# Altium schematic sheet Chipolino_revA.SchDoc
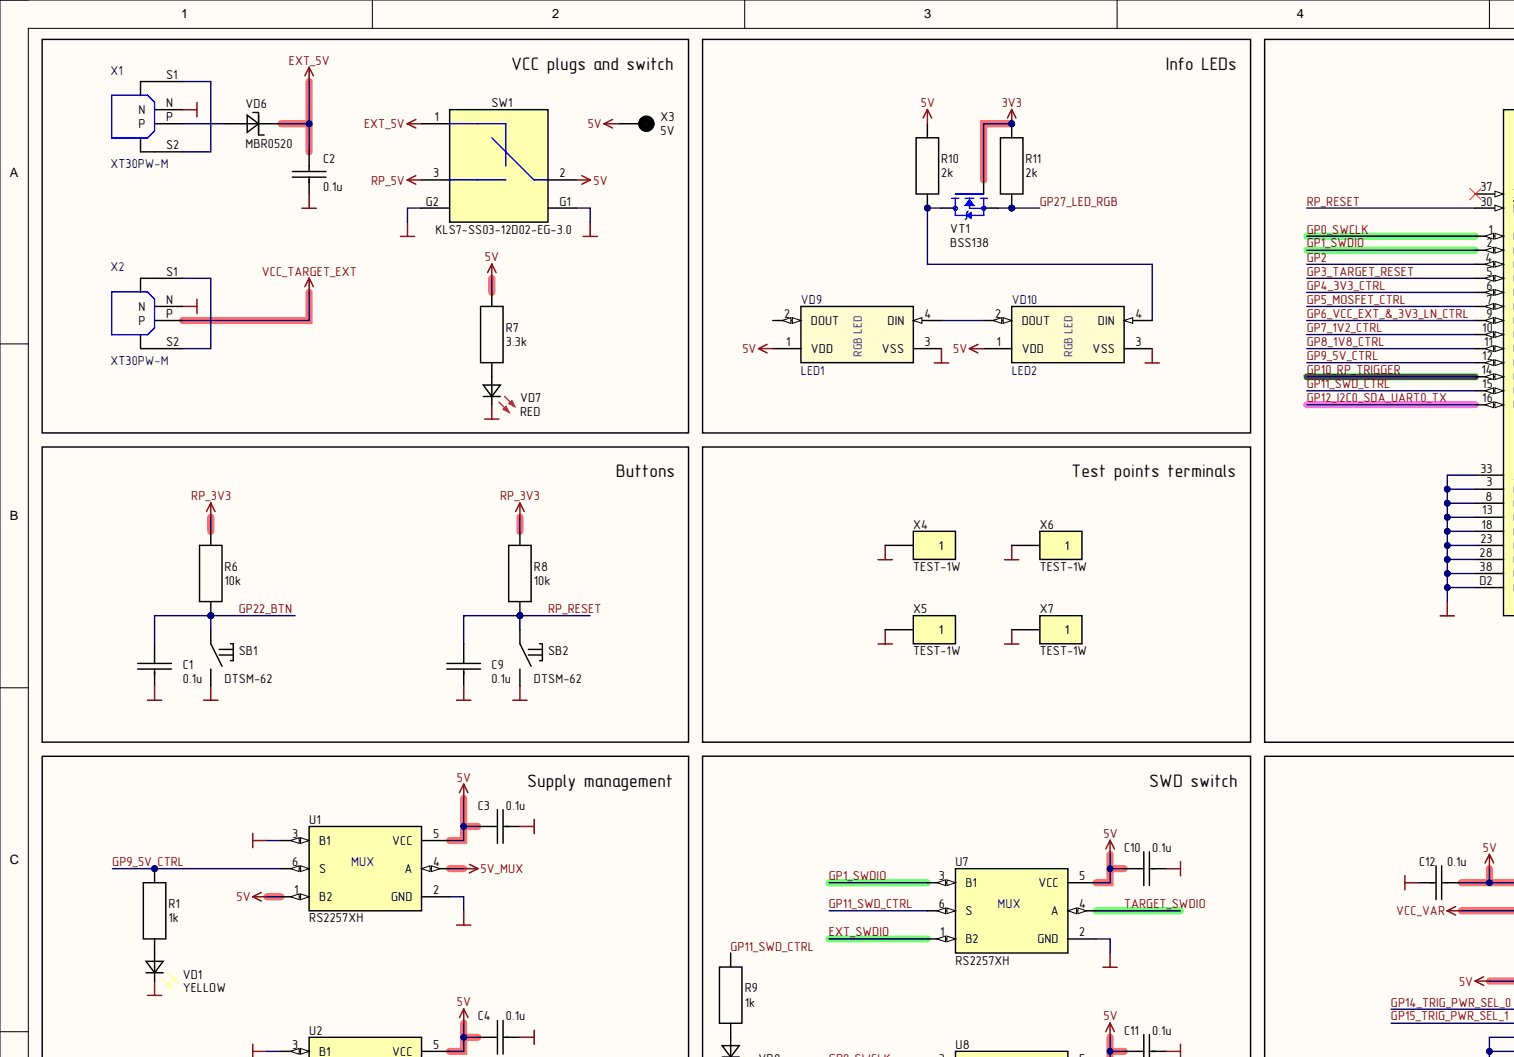
[diagram p0: Chipolino_revA.SchDoc, top left]
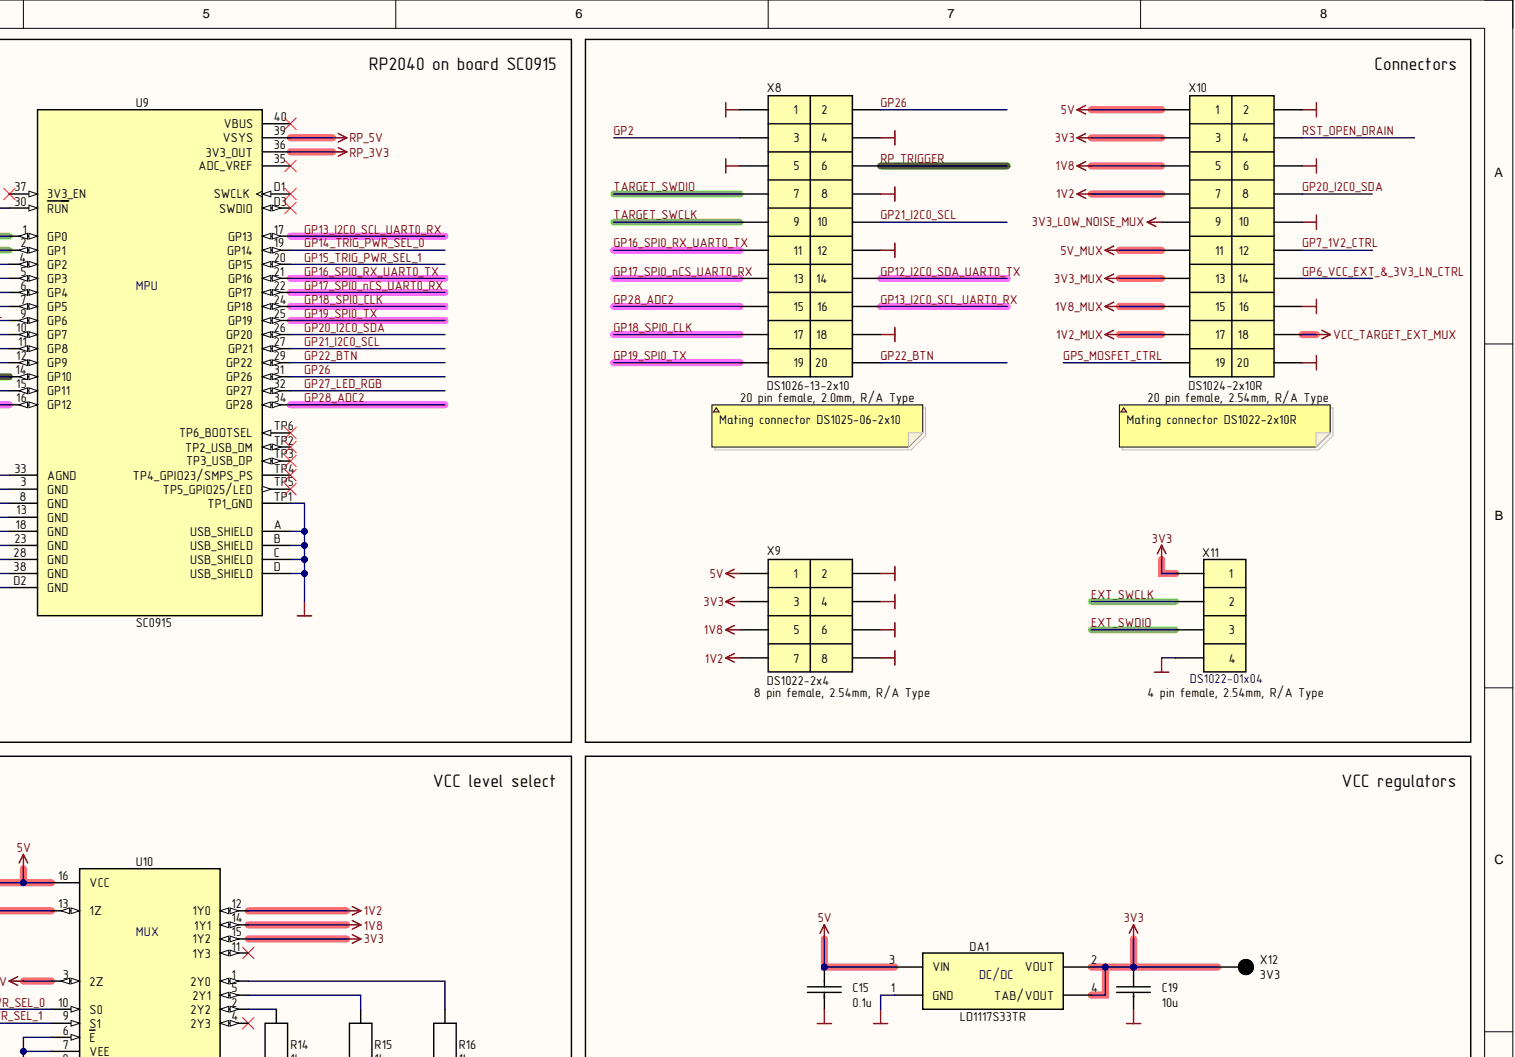
[diagram p1: Chipolino_revA.SchDoc, top right]
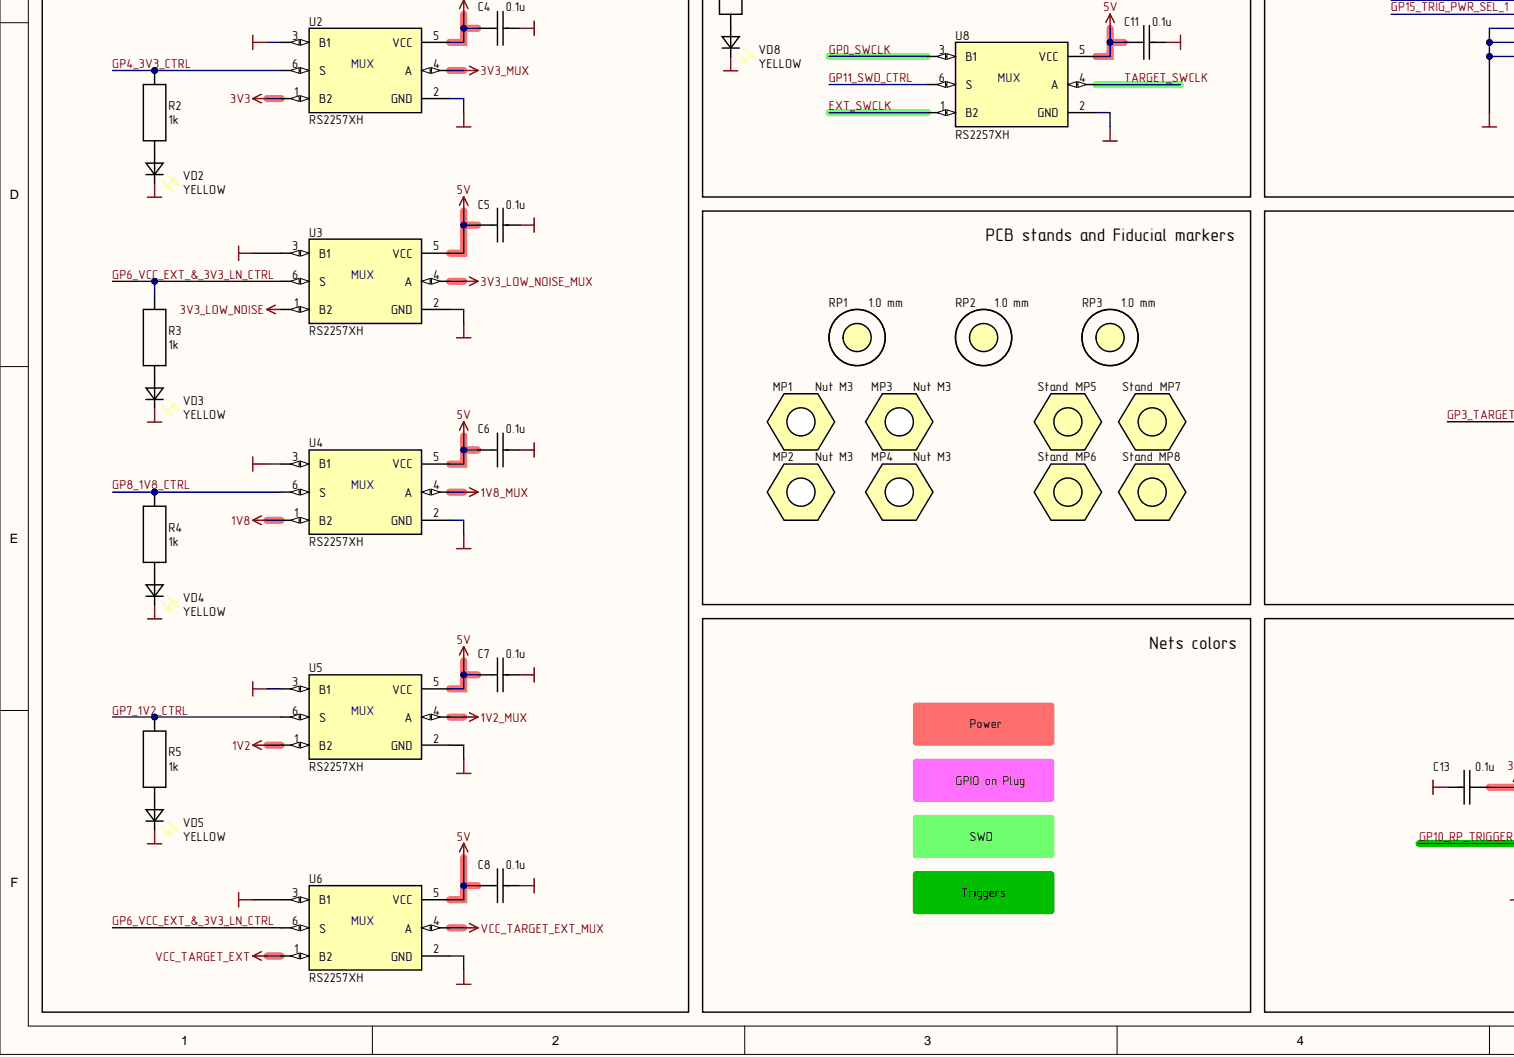
[diagram p2: Chipolino_revA.SchDoc, bottom left]
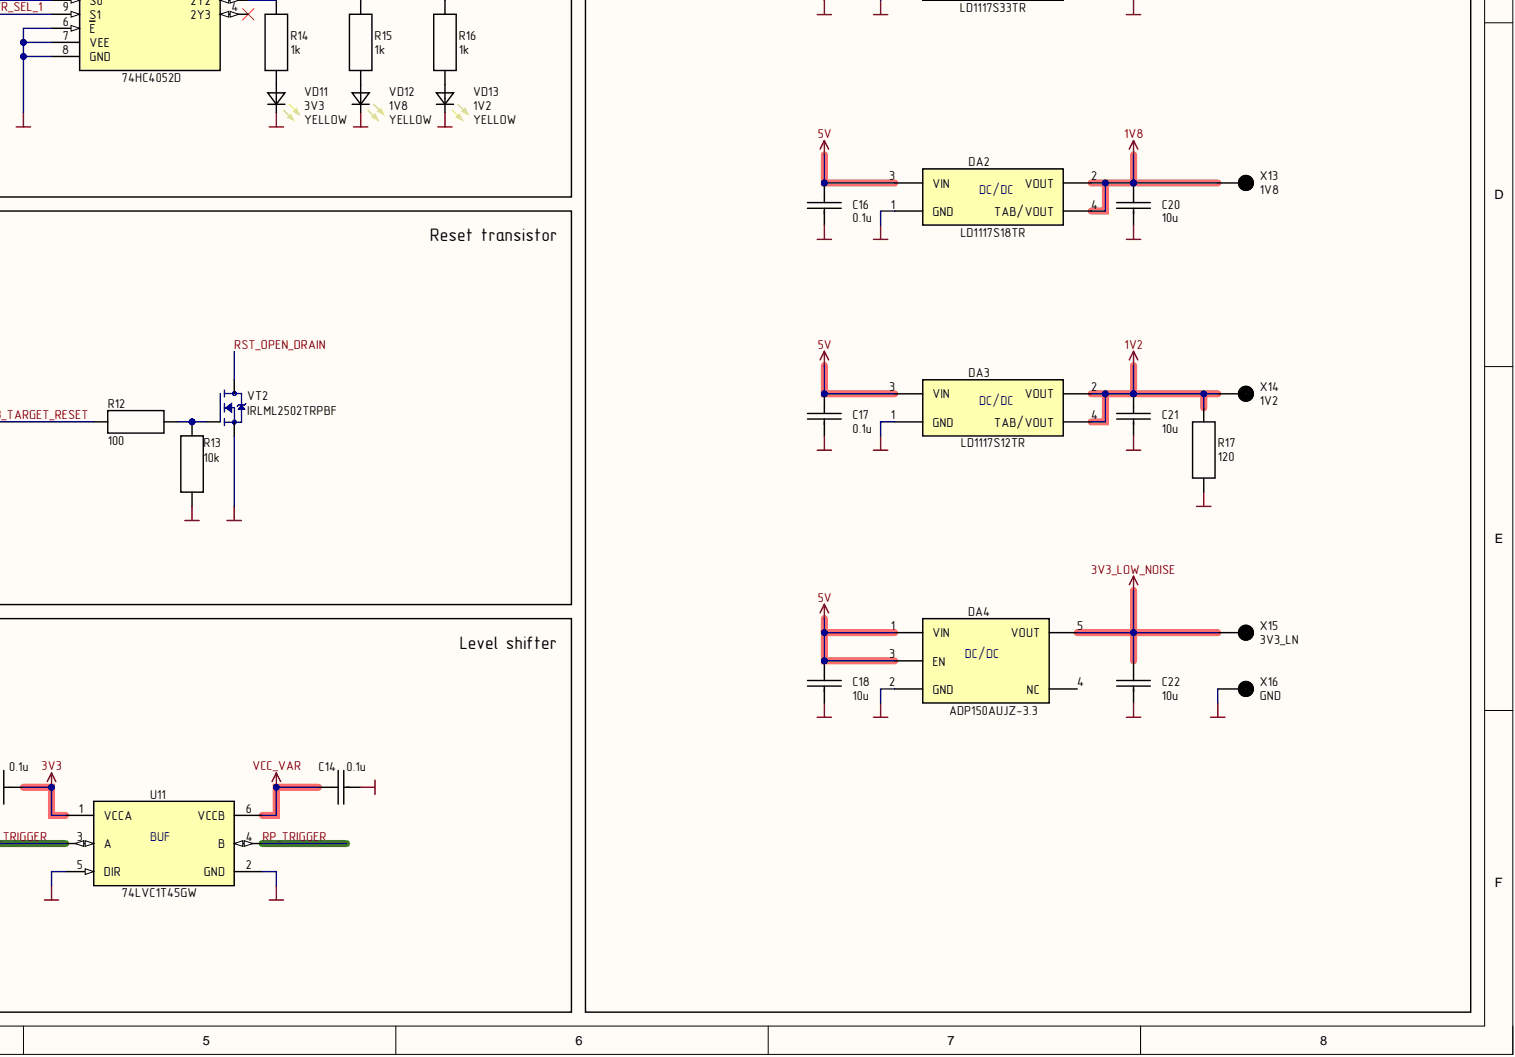
[diagram p3: Chipolino_revA.SchDoc, bottom right]

## Parts
C1  value 0.1u  [CH0603C]  (hidden comment: Ceramic capacitor, 0603, 0.1u, ±10%, 50V, X7R, -55...+125°C)
C2  value 0.1u  [CH0603C]  (hidden comment: Ceramic capacitor, 0603, 0.1u, ±10%, 50V, X7R, -55...+125°C)
C3  value 0.1u  [CH0603C]  (hidden comment: Ceramic capacitor, 0603, 0.1u, ±10%, 50V, X7R, -55...+125°C)
C4  value 0.1u  [CH0603C]  (hidden comment: Ceramic capacitor, 0603, 0.1u, ±10%, 50V, X7R, -55...+125°C)
C5  value 0.1u  [CH0603C]  (hidden comment: Ceramic capacitor, 0603, 0.1u, ±10%, 50V, X7R, -55...+125°C)
C6  value 0.1u  [CH0603C]  (hidden comment: Ceramic capacitor, 0603, 0.1u, ±10%, 50V, X7R, -55...+125°C)
C7  value 0.1u  [CH0603C]  (hidden comment: Ceramic capacitor, 0603, 0.1u, ±10%, 50V, X7R, -55...+125°C)
C8  value 0.1u  [CH0603C]  (hidden comment: Ceramic capacitor, 0603, 0.1u, ±10%, 50V, X7R, -55...+125°C)
C9  value 0.1u  [CH0603C]  (hidden comment: Ceramic capacitor, 0603, 0.1u, ±10%, 50V, X7R, -55...+125°C)
C10  value 0.1u  [CH0603C]  (hidden comment: Ceramic capacitor, 0603, 0.1u, ±10%, 50V, X7R, -55...+125°C)
C11  value 0.1u  [CH0603C]  (hidden comment: Ceramic capacitor, 0603, 0.1u, ±10%, 50V, X7R, -55...+125°C)
C12  value 0.1u  [CH0603C]  (hidden comment: Ceramic capacitor, 0603, 0.1u, ±10%, 50V, X7R, -55...+125°C)
C13  value 0.1u  [CH0603C]  (hidden comment: Ceramic capacitor, 0603, 0.1u, ±10%, 50V, X7R, -55...+125°C)
C14  value 0.1u  [CH0603C]  (hidden comment: Ceramic capacitor, 0603, 0.1u, ±10%, 50V, X7R, -55...+125°C)
C15  value 0.1u  [CH0603C]  (hidden comment: Ceramic capacitor, 0603, 0.1u, ±10%, 50V, X7R, -55...+125°C)
C16  value 0.1u  [CH0603C]  (hidden comment: Ceramic capacitor, 0603, 0.1u, ±10%, 50V, X7R, -55...+125°C)
C17  value 0.1u  [CH0603C]  (hidden comment: Ceramic capacitor, 0603, 0.1u, ±10%, 50V, X7R, -55...+125°C)
C18  value 10u  [CH0603C]  (hidden comment: Ceramic capacitor, 0603, 10u, ±10%, 16V, X7R, -55...+125°C)
C19  value 10u  [CH0603C]  (hidden comment: Ceramic capacitor, 0603, 10u, ±10%, 16V, X7R, -55...+125°C)
C20  value 10u  [CH0603C]  (hidden comment: Ceramic capacitor, 0603, 10u, ±10%, 16V, X7R, -55...+125°C)
C21  value 10u  [CH0603C]  (hidden comment: Ceramic capacitor, 0603, 10u, ±10%, 16V, X7R, -55...+125°C)
C22  value 10u  [CH0603C]  (hidden comment: Ceramic capacitor, 0603, 10u, ±10%, 16V, X7R, -55...+125°C)
DA1  LD1117S33TR  [SOT-223]
DA2  LD1117S18TR  [SOT-223]
DA3  LD1117S12TR  [SOT-223]
DA4  ADP150AUJZ-3.3  [SOT23-5]
MP1  Nut M3  [Stand]  (mechanical)
MP2  Nut M3  [Stand]  (mechanical)
MP3  Nut M3  [Stand]  (mechanical)
MP4  Nut M3  [Stand]  (mechanical)
MP5  Stand  [Stand]
MP6  Stand  [Stand]
MP7  Stand  [Stand]
MP8  Stand  [Stand]
R1  value 1k  [CH0603R]  (hidden comment: Resistor thick-film, 0603, 1k, ±5%, 0.1W, -55...+125°C)
R2  value 1k  [CH0603R]  (hidden comment: Resistor thick-film, 0603, 1k, ±5%, 0.1W, -55...+125°C)
R3  value 1k  [CH0603R]  (hidden comment: Resistor thick-film, 0603, 1k, ±5%, 0.1W, -55...+125°C)
R4  value 1k  [CH0603R]  (hidden comment: Resistor thick-film, 0603, 1k, ±5%, 0.1W, -55...+125°C)
R5  value 1k  [CH0603R]  (hidden comment: Resistor thick-film, 0603, 1k, ±5%, 0.1W, -55...+125°C)
R6  value 10k  [CH0603R]  (hidden comment: Resistor thick-film, 0603, 10k, ±5%, 0.1W, -55...+125°C)
R7  value 3.3k  [CH0603R]  (hidden comment: Resistor thick-film, 0603, 3.3k, ±5%, 0.1W, -55...+125°C)
R8  value 10k  [CH0603R]  (hidden comment: Resistor thick-film, 0603, 10k, ±5%, 0.1W, -55...+125°C)
R9  value 1k  [CH0603R]  (hidden comment: Resistor thick-film, 0603, 1k, ±5%, 0.1W, -55...+125°C)
R10  value 2k  [CH0603R]  (hidden comment: Resistor thick-film, 0603, 2k, ±1%, 0.1W, -55...+125°C)
R11  value 2k  [CH0603R]  (hidden comment: Resistor thick-film, 0603, 2k, ±1%, 0.1W, -55...+125°C)
R12  value 100  [CH0603R]  (hidden comment: Resistor thick-film, 0603, 100, ±1%, 0.1W, -55...+125°C)
R13  value 10k  [CH0603R]  (hidden comment: Resistor thick-film, 0603, 10k, ±5%, 0.1W, -55...+125°C)
R14  value 1k  [CH0603R]  (hidden comment: Resistor thick-film, 0603, 1k, ±5%, 0.1W, -55...+125°C)
R15  value 1k  [CH0603R]  (hidden comment: Resistor thick-film, 0603, 1k, ±5%, 0.1W, -55...+125°C)
R16  value 1k  [CH0603R]  (hidden comment: Resistor thick-film, 0603, 1k, ±5%, 0.1W, -55...+125°C)
R17  value 120  [CH0603R]  (hidden comment: Resistor thick-film, 0603, 120, ±1%, 0.1W, -55...+125°C)
RP1  1.0 mm  [REPER-10]  (no BOM)
RP2  1.0 mm  [REPER-10]  (no BOM)
RP3  1.0 mm  [REPER-10]  (no BOM)
SB1  GP22_BTN  [DTSM-62]
SB2  RP_RESET  [DTSM-62]
SW1  KLS7-SS03-12D02-EG-3.0  [KLS7-SS03-12D02]
U1  RS2257XH  [SOT23-6]
U2  RS2257XH  [SOT23-6]
U3  RS2257XH  [SOT23-6]
U4  RS2257XH  [SOT23-6]
U5  RS2257XH  [SOT23-6]
U6  RS2257XH  [SOT23-6]
U7  RS2257XH  [SOT23-6]
U8  RS2257XH  [SOT23-6]
U9  SC0915  [MODULE_SC0915_TH]
U10  74HC4052D  [SOIC_16]
U11  74LVC1T45GW  [TSSOP-6 (SOT363)]
VD1  GP9_5V_CTRL  Color: YELLOW  [LED: 0603Y]
VD2  GP4_3V3_CTRL  Color: YELLOW  [LED: 0603Y]
VD3  Color: YELLOW  [LED: 0603Y]  (hidden comment: GP6_3V3_LN_CTRL)
VD4  GP8_1V8_CTRL  Color: YELLOW  [LED: 0603Y]
VD5  GP7_1V2_CTRL  Color: YELLOW  [LED: 0603Y]
VD6  MBR0520  [SOD123]
VD7  Color: RED  [LED: 0603R]  (hidden comment: 5V)
VD8  GP11_SWD_CTRL  Color: YELLOW  [LED: 0603Y]
VD9  LED1  [LED_3535]
VD10  LED2  [LED_3535]
VD11  3V3  Color: YELLOW  [LED: 0603Y]
VD12  1V8  Color: YELLOW  [LED: 0603Y]
VD13  1V2  Color: YELLOW  [LED: 0603Y]
VT1  BSS138  [SOT23]
VT2  IRLML2502TRPBF  [SOT23]
X1  XT30PW-M  [XT30PW-M]
X2  XT30PW-M  [XT30PW-M]
X3  5V  [Test point]  (no BOM)
X4  PartNumber: TEST-1W  [TEST-1W 1pin MULTICOMP]  (hidden comment: GND)
X5  PartNumber: TEST-1W  [TEST-1W 1pin MULTICOMP]  (hidden comment: GND)
X6  PartNumber: TEST-1W  [TEST-1W 1pin MULTICOMP]  (hidden comment: GND)
X7  PartNumber: TEST-1W  [TEST-1W 1pin MULTICOMP]  (hidden comment: GND)
X8  DS1026-13-2x10  [DS1026-13-2x10]
X9  DS1022-2x4  [DS1022-2x4]
X10  DS1024-2x10R  [DS1024-2x10R]
X11  PartNumber: DS1022-01x04  [DS1022-01-4  2.54]  (hidden comment: External SWD)
X12  3V3  [Test point]  (no BOM)
X13  1V8  [Test point]  (no BOM)
X14  1V2  [Test point]  (no BOM)
X15  3V3_LN  [Test point]  (no BOM)
X16  GND  [Test point]  (no BOM)

## Nets
1V2: C21.2 DA3.2(VOUT) DA3.4(TAB/VOUT) R17.2 U5.1(B2) U10.12(1Y0) X9.7 X10.7 X14.1
1V2_MUX: U5.4(A) X10.17
1V8: C20.2 DA2.2(VOUT) DA2.4(TAB/VOUT) U4.1(B2) U10.14(1Y1) X9.5 X10.5 X13.1
1V8_MUX: U4.4(A) X10.15
3V3: C13.2 C19.2 DA1.2(VOUT) DA1.4(TAB/VOUT) R11.2 U2.1(B2) U10.15(1Y2) U11.1(VCCA) VT1.1 X9.3 X10.3 X11.1 X12.1
3V3_LOW_NOISE: C22.2 DA4.5(VOUT) U3.1(B2) X15.1
3V3_LOW_NOISE_MUX: U3.4(A) X10.9
3V3_MUX: U2.4(A) X10.13
5V: C3.2 C4.2 C5.2 C6.2 C7.2 C8.2 C10.2 C11.2 C12.2 C15.2 C16.2 C17.2 C18.2 DA1.3(VIN) DA2.3(VIN) DA3.3(VIN) DA4.1(VIN) DA4.3(EN) R7.2 R10.2 SW1.2 U1.1(B2) U1.5(VCC) U2.5(VCC) U3.5(VCC) U4.5(VCC) U5.5(VCC) U6.5(VCC) U7.5(VCC) U8.5(VCC) U10.3(2Z) U10.16(VCC) VD9.1(VDD) VD10.1(VDD) X3.1 X9.1 X10.1
5V_MUX: U1.4(A) X10.11
EXT_5V: C2.2 SW1.1 VD6.1
GND: C1.1 C2.1 C3.1 C4.1 C5.1 C6.1 C7.1 C8.1 C9.1 C10.1 C11.1 C12.1 C13.1 C14.1 C15.1 C16.1 C17.1 C18.1 C19.1 C20.1 C21.1 C22.1 DA1.1(GND) DA2.1(GND) DA3.1(GND) DA4.2(GND) R13.1 R17.1 SB1.2 SB2.2 SW1.G1 SW1.G2 U1.2(GND) U1.3(B1) U2.2(GND) U2.3(B1) U3.2(GND) U3.3(B1) U4.2(GND) U4.3(B1) U5.2(GND) U5.3(B1) U6.2(GND) U6.3(B1) U7.2(GND) U8.2(GND) U9.3(GND) U9.8(GND) U9.13(GND) U9.18(GND) U9.23(GND) U9.28(GND) U9.33(AGND) U9.38(GND) U9.A(USB_SHIELD) U9.B(USB_SHIELD) U9.C(USB_SHIELD) U9.D(USB_SHIELD) U9.D2(GND) U9.TP1(TP1_GND) U10.6(E) U10.7(VEE) U10.8(GND) U11.2(GND) U11.5(DIR) VD1.1 VD2.1 VD3.1 VD4.1 VD5.1 VD7.1 VD8.1 VD9.3(VSS) VD10.3(VSS) VD11.1 VD12.1 VD13.1 VT2.2 X1.N X2.N X4.1 X5.1 X6.1 X7.1 X8.1 X8.4 X8.5 X8.8 X8.12 X8.18 X9.2 X9.4 X9.6 X9.8 X10.2 X10.6 X10.10 X10.16 X10.20 X11.4 X16.1
RP_3V3: R6.2 R8.2 U9.36(3V3_OUT)
RP_5V: SW1.3 U9.39(VSYS)
VCC_TARGET_EXT: U6.1(B2) X2.P
VCC_TARGET_EXT_MUX: U6.4(A) X10.18
VCC_VAR: C14.2 U10.13(1Z) U11.6(VCCB)
EXT_SWCLK: U8.1(B2) X11.2
EXT_SWDIO: U7.1(B2) X11.3
GP0_SWCLK: U8.3(B1) U9.1(GP0)
GP1_SWDIO: U7.3(B1) U9.2(GP1)
GP2: U9.4(GP2) X8.3
GP3_TARGET_RESET: R12.1 U9.5(GP3)
GP4_3V3_CTRL: R2.2 U2.6(S) U9.6(GP4)
GP5_MOSFET_CTRL: U9.7(GP5) X10.19
GP6_VCC_EXT_&_3V3_LN_CTRL: R3.2 U3.6(S) U6.6(S) U9.9(GP6) X10.14
GP7_1V2_CTRL: R5.2 U5.6(S) U9.10(GP7) X10.12
GP8_1V8_CTRL: R4.2 U4.6(S) U9.11(GP8)
GP9_5V_CTRL: R1.2 U1.6(S) U9.12(GP9)
GP10_RP_TRIGGER: U9.14(GP10) U11.3(A)
GP11_SWD_CTRL: R9.2 U7.6(S) U8.6(S) U9.15(GP11)
GP12_I2C0_SDA_UART0_TX: U9.16(GP12) X8.14
GP13_I2C0_SCL_UART0_RX: U9.17(GP13) X8.16
GP14_TRIG_PWR_SEL_0: U9.19(GP14) U10.10(S0)
GP15_TRIG_PWR_SEL_1: U9.20(GP15) U10.9(S1)
GP16_SPI0_RX_UART0_TX: U9.21(GP16) X8.11
GP17_SPI0_nCS_UART0_RX: U9.22(GP17) X8.13
GP18_SPI0_CLK: U9.24(GP18) X8.17
GP19_SPI0_TX: U9.25(GP19) X8.19
GP20_I2C0_SDA: U9.26(GP20) X10.8
GP21_I2C0_SCL: U9.27(GP21) X8.10
GP22_BTN: C1.2 R6.1 SB1.1 U9.29(GP22) X8.20
GP26: U9.31(GP26) X8.2
GP27_LED_RGB: R11.1 U9.32(GP27) VT1.2
GP28_ADC2: U9.34(GP28) X8.15
RP_RESET: C9.2 R8.1 SB2.1 U9.30(RUN)
RP_TRIGGER: U11.4(B) X8.6
RST_OPEN_DRAIN: VT2.3 X10.4
TARGET_SWCLK: U8.4(A) X8.9
TARGET_SWDIO: U7.4(A) X8.7
NetR1_1: R1.1 VD1.2
NetR2_1: R2.1 VD2.2
NetR3_1: R3.1 VD3.2
NetR4_1: R4.1 VD4.2
NetR5_1: R5.1 VD5.2
NetR7_1: R7.1 VD7.2
NetR9_1: R9.1 VD8.2
NetR10_1: R10.1 VD10.4(DIN) VT1.3
NetR12_2: R12.2 R13.2 VT2.1
NetR14_1: R14.1 VD11.2
NetR14_2: R14.2 U10.2(2Y2)
NetR15_1: R15.1 VD12.2
NetR15_2: R15.2 U10.5(2Y1)
NetR16_1: R16.1 VD13.2
NetR16_2: R16.2 U10.1(2Y0)
NetVD6_2: VD6.2 X1.P
NetVD9_4: VD9.4(DIN) VD10.2(DOUT)
NetX1_S1: X1.S1 X1.S2
NetX2_S1: X2.S1 X2.S2

Not connected: DA4 4(NC); MP5 1; MP6 1; MP7 1; MP8 1; RP1 1; RP2 1; RP3 1; U9 35(ADC_VREF) 37(3V3_EN) 40(VBUS) D1(SWCLK) D3(SWDIO) TP2(TP2_USB_DM) TP3(TP3_USB_DP) TP4(TP4_GPIO23/SMPS_PS) TP5(TP5_GPIO25/LED) TP6(TP6_BOOTSEL); U10 4(2Y3) 11(1Y3); VD9 2(DOUT)

## Library data of the parts
C1-C17 = Capacitor: Manufacturer: Murata; PartNumber: GRM188R71H104K; Type: SMD; Component Kind: Standard; ComponentType: Ceramic capacitor; Description/Value: Ceramic capacitor, 0603, 0.1u, ±10%, 50V, X7R, -55...+125°C; HelpURL: ?; Library Name: Common Lib.SchLib; Package: 0603; Temperature: -55...+125°C; Tolerance: ±10%; TypeDielectric: X7R; Voltage: 50V
C18-C22 = Capacitor: Manufacturer: Murata; PartNumber: GRM188R61C106KAALD; Type: SMD; Component Kind: Standard; ComponentType: Ceramic capacitor; Description/Value: Ceramic capacitor, 0603, 10u, ±10%, 16V, X7R, -55...+125°C; HelpURL: ?; Library Name: Common Lib.SchLib; Package: 0603; Temperature: -55...+125°C; Tolerance: ±10%; TypeDielectric: X7R; Voltage: 16V
DA1 = LD1117S33TR: LDO; Description/Value: LDO voltage regulator, +3.3V, 800mA [SOT-223]; Component Kind: Standard; Library Name: Common Lib.SchLib; Package: SOT223; PartNumber: LD1117S33TR
DA2 = LD1117S18TR: LDO; Description/Value: LDO voltage regulator, +1.8V, 800mA [SOT-223]; Component Kind: Standard; Library Name: Common Lib.SchLib; Package: SOT223; PartNumber: LD1117S18TR
DA3 = LD1117S12TR: LDO; Description/Value: LDO voltage regulator, +1.2V, 800mA [SOT-223]; Component Kind: Standard; Library Name: Common Lib.SchLib; Package: SOT223; PartNumber: LD1117S12TR
DA4 = ADP150AUJZ-3.3: LDO; Description/Value: LDO voltage regulator, Ultra-Low Noise, +3.3V, 150mA [SOT23-5]; Component Kind: Standard; Library Name: Common Lib.SchLib; Package: SOT23-5; PartNumber: ADP150AUJZ-3.3
MP1-MP4 = nut: PartNumber: Nut M3 DIN 934; Description/Value: Hexagon Nut M3 DIN 934; Library Name: Common Lib.SchLib
MP5-MP8 = stand: PartNumber: PCHSN-10; Description/Value: PCB brass stand, M3, 10mm; Component Kind: Standard; Library Name: Common Lib.SchLib
R1-R5 R9 R14-R16 = Resistor: PartNumber: RC0603JR-071KL; Component Kind: Standard; ComponentType: Resistor thick-film; Description/Value: Resistor thick-film, 0603, 1k, ±5%, 0.1W, -55...+125°C; Library Name: Common Lib.SchLib; Package: 0603; Power: 0.1W; Temperature: -55...+125°C; Tolerance: ±5%
R6 R8 = Resistor: PartNumber: RC0603JR-0710KL; Component Kind: Standard; ComponentType: Resistor thick-film; Description/Value: Resistor thick-film, 0603, 10k, ±5%, 0.1W, -55...+125°C; Library Name: Common Lib.SchLib; Package: 0603; Power: 0.1W; Temperature: -55...+125°C; Tolerance: ±5%
R7 = Resistor: PartNumber: RC0603JR-073K3L; Component Kind: Standard; ComponentType: Resistor thick-film; Description/Value: Resistor thick-film, 0603, 3.3k, ±5%, 0.1W, -55...+125°C; Library Name: Common Lib.SchLib; Package: 0603; Power: 0.1W; Temperature: -55...+125°C; Tolerance: ±5%
R10 R11 = Resistor: PartNumber: RC0603JR-072KL; Component Kind: Standard; ComponentType: Resistor thick-film; Description/Value: Resistor thick-film, 0603, 2k, ±1%, 0.1W, -55...+125°C; Library Name: Common Lib.SchLib; Package: 0603; Power: 0.1W; Temperature: -55...+125°C; Tolerance: ±1%
R12 = Resistor: Manufacturer: Yageo; PartNumber: RC0603FR-07100RL; Component Kind: Standard; ComponentType: Resistor thick-film; Description/Value: Resistor thick-film, 0603, 100, ±1%, 0.1W, -55...+125°C; HelpURL: https://datasheet.lcsc.com/lcsc/2009261810_YAGEO-RL0805FR-070R33L_C724024.pdf; Library Name: Common Lib.SchLib; Package: 0603; Power: 0.1W; Temperature: -55...+125°C; Tolerance: ±1%; Type: SMD
R13 = Resistor: Manufacturer: Yageo; PartNumber: RC0603JR-0710KL; Component Kind: Standard; ComponentType: Resistor thick-film; Description/Value: Resistor thick-film, 0603, 10k, ±5%, 0.1W, -55...+125°C; HelpURL: https://datasheet.lcsc.com/lcsc/2009261810_YAGEO-RL0805FR-070R33L_C724024.pdf; Library Name: Common Lib.SchLib; Package: 0603; Power: 0.1W; Temperature: -55...+125°C; Tolerance: ±5%; Type: SMD
R17 = Resistor: PartNumber: RC0603JR-07120RL; Component Kind: Standard; ComponentType: Resistor thick-film; Description/Value: Resistor thick-film, 0603, 120, ±1%, 0.1W, -55...+125°C; Library Name: Common Lib.SchLib; Package: 0603; Power: 0.1W; Temperature: -55...+125°C; Tolerance: ±1%
RP1-RP3 = CMP-00005-2: Design Item ID: REPER; Source: Common Lib.SchLib; Library Name: Positive Labs Server
SB1 SB2 = Button: 6x6 mm; Description/Value: Surface Mount Tactile Switch Type 6*6, DTSM-62; Component Kind: Standard; ComponentType: Surface Mount Tactile Switch Type 6*6; Library Name: Common Lib.SchLib; PartNumber: DTSM-62
SW1 = switch: Description/Value: Slide switch, KLS7-SS03-12D02-EG-3.0; Component Kind: Standard; Library Name: Common Lib.SchLib; PartNumber: KLS7-SS03-12D02-EG-3.0
U1-U8 = CMP-00174-2: Analog multiplexer 1 channel, SOT23-6; Component Kind: Standard; Description/Value: Analog multiplexer 1 channel, SOT23-6; PartNumber: RS2257XH; Library Name: Positive Labs Server
U9 = SC0915: Raspberry Pi Pico; Description/Value: Development board RP2040; Component Kind: Standard; Library Name: Common Lib.SchLib; PartNumber: SC0915
U10 = 74HC4052D: Dual single-pole quad-throw analog switch; Description/Value: Dual single-pole quad-throw analog switch, SOIC-16; Component Kind: Standard; Library Name: Common Lib.SchLib; Package: SOIC-16; PartNumber: 74HC4052D
U11 = 74LVC1T45GW: Voltage Level Translator Bidirectional 1 Circuit 1 Channel 420Mbps 6-TSSOP; Description/Value: Voltage Level Translator Bidirectional 1 Circuit 1 Channel  TSOP-6; Component Kind: Standard; Library Name: Common Lib.SchLib; Package: TSOP-6; PartNumber: 74LVC1T45GW
VD1-VD5 VD8 VD11-VD13 = LED_0603Y: PartNumber: GNL-0603UYC; Description/Value: LED, 0603, YELLOW; Component Kind: Standard; ComponentType: LED; Library Name: Common Lib.SchLib; Package: 0603
VD6 = Diode Shottky: Description/Value: Diode Shottky,  SOD123; Case: SOD123; Component Kind: Standard; Library Name: Common Lib.SchLib; PartNumber: MBR0520
VD7 = LED_0603R: PartNumber: GNL-0603SRC; Description/Value: LED, 0603, RED; Component Kind: Standard; ComponentType: LED; Library Name: Common Lib.SchLib; Package: 0603
VD9 VD10 = WS2812B-Mini: SMD integrated light source RGB LED, LED3535; ProcessType: SMT; Type: LEDs; Component Kind: Standard; Description/Value: SMD integrated light source RGB LED, LED3535; LCSC Part #: C527089; Library Name: Common Lib.SchLib; Package: LED 3535; PartNumber: WS2812B-Mini
VT1 = MOSFET N: RoHS Compliant | Industry-leading quality | Fast Switching | Low Profile _less than 1.1mm_ | SOT-23 Footprint; Description/Value: N-MOSFET Transistor, 50V, 0.2A, SOT-23-23; Component Kind: Standard; Library Name: Common Lib.SchLib; Package: SOT-23; PartNumber: BSS138
VT2 = MOSFET N: RoHS Compliant | Industry-leading quality | Fast Switching | Low Profile _less than 1.1mm_ | SOT-23 Footprint; Description/Value: N-MOSFET Transistor, 20V, 4.2A, SOT-23-23; Component Kind: Standard; Library Name: Common Lib.SchLib; Package: SOT-23; PartNumber: IRLML2502TRPBF
X1 X2 = XT30PW-M: Socket; DC supply; XT30; male; PIN: 2; on PCBs; THT; Colour: yellow; Component Kind: Standard; Description/Value: Socket; DC supply; XT30; male; PIN: 2; on PCBs; THT; Colour: yellow; Library Name: Common Lib.SchLib; PartNumber: XT30PW-M
X3 X12-X16 = Test Point: Library Name: Common Lib.SchLib
X4-X7 = 1-Connector: Description/Value: PCB Test Point Through Hole Mount; Component Kind: Standard; Library Name: Common Lib.SchLib
X8 = 20-Connector: Description/Value: PLD connector 20 pin, 2.0mm, Female, Right angel, DS1026-13-2x10; Component Kind: Standard; ComponentKind: PLD connector 20 pin, 2.0mm, Female, Right angel; Library Name: Common Lib.SchLib; PartNumber: DS1026-13-2x10
X9 = 2x4-Connector: Description/Value: PLD connector 2x4 pin, 2.54mm, Right angel, DS1022-2x4; Component Kind: Standard; ComponentKind: PLD connector 2x4 pin, 2.54mm, Right angel; Library Name: Common Lib.SchLib; PartNumber: DS1022-2x4
X10 = 20-Connector: Description/Value: PLD connector 20 pin, 2.54mm, Female, Right angel, DS1024-2x10R; Component Kind: Standard; ComponentKind: PLD connector 20 pin, 2.54mm, Female, Right angel; Library Name: Common Lib.SchLib; PartNumber: DS1024-2x10R
X11 = 4-Connector: Description/Value: PLS connector 4 pin, 2.54mm; Component Kind: Standard; ComponentKind: PLS connector 4 pin, 2.54mm; Library Name: Common Lib.SchLib
